# Altium schematic sheet MCU.SchDoc
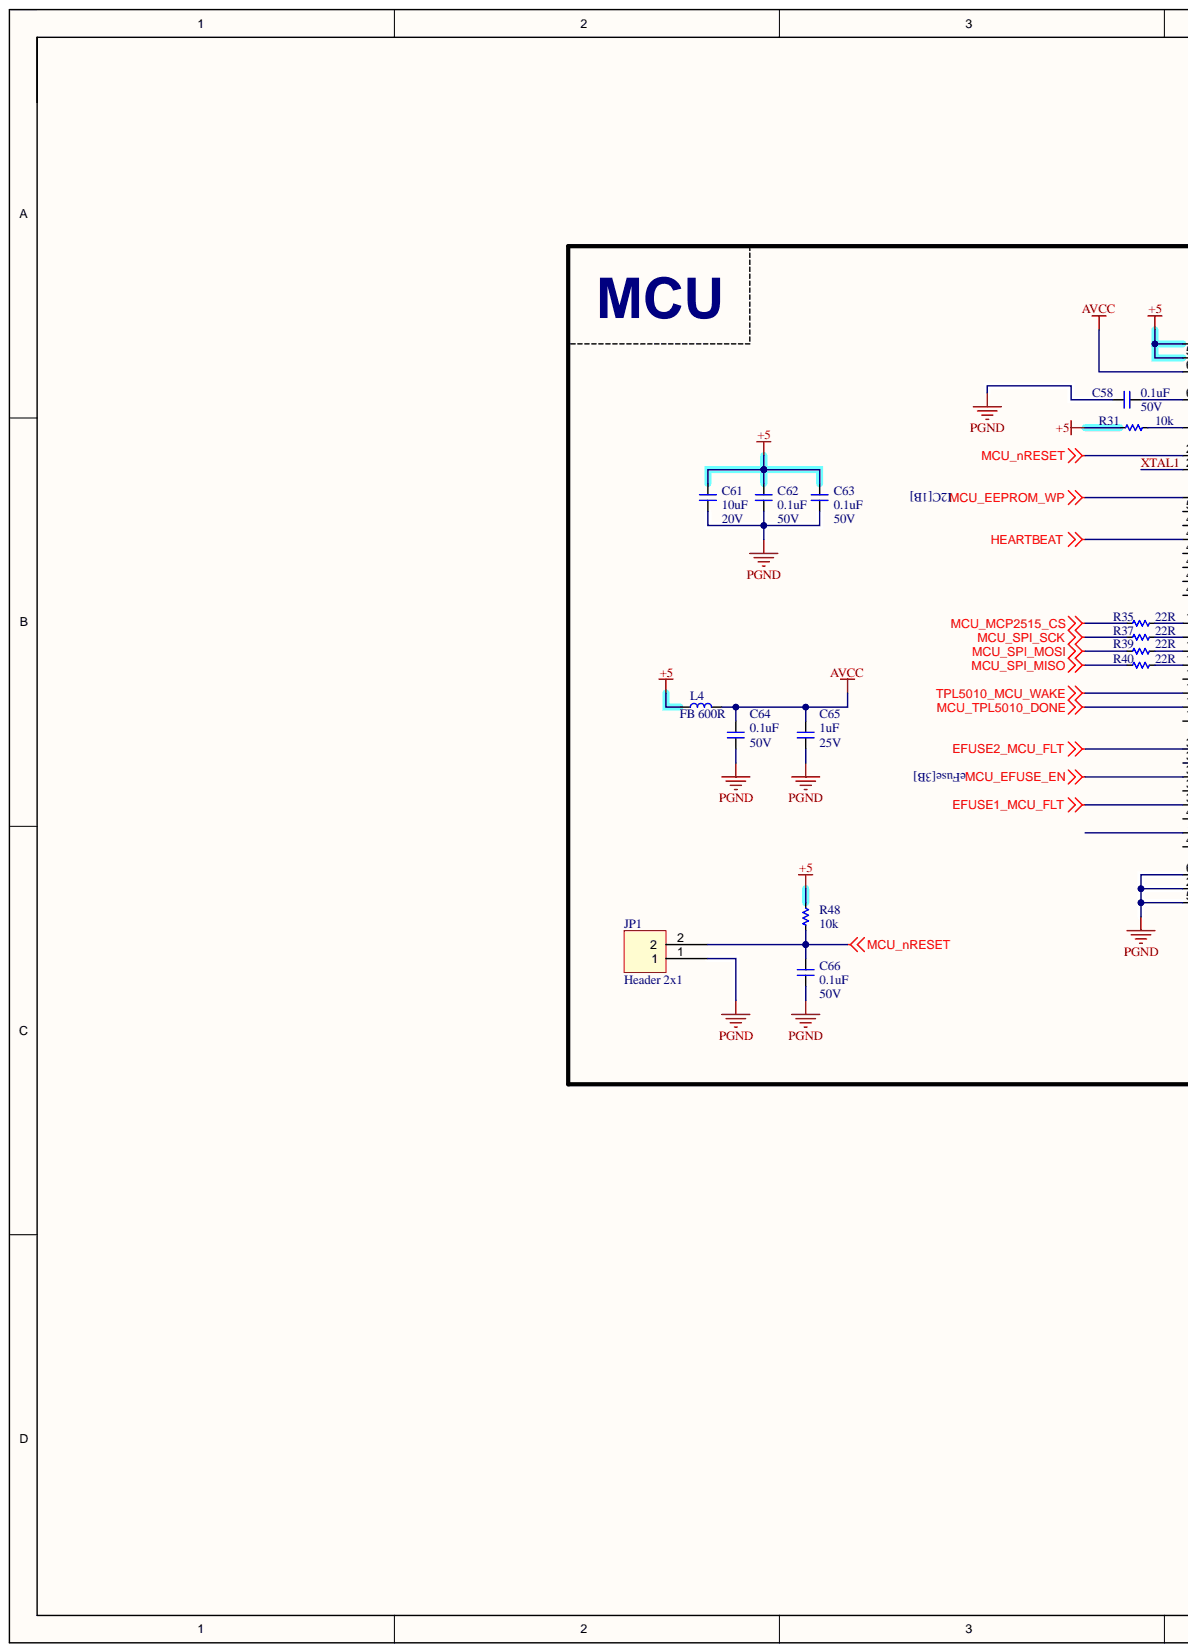
[diagram p0: MCU.SchDoc, left]
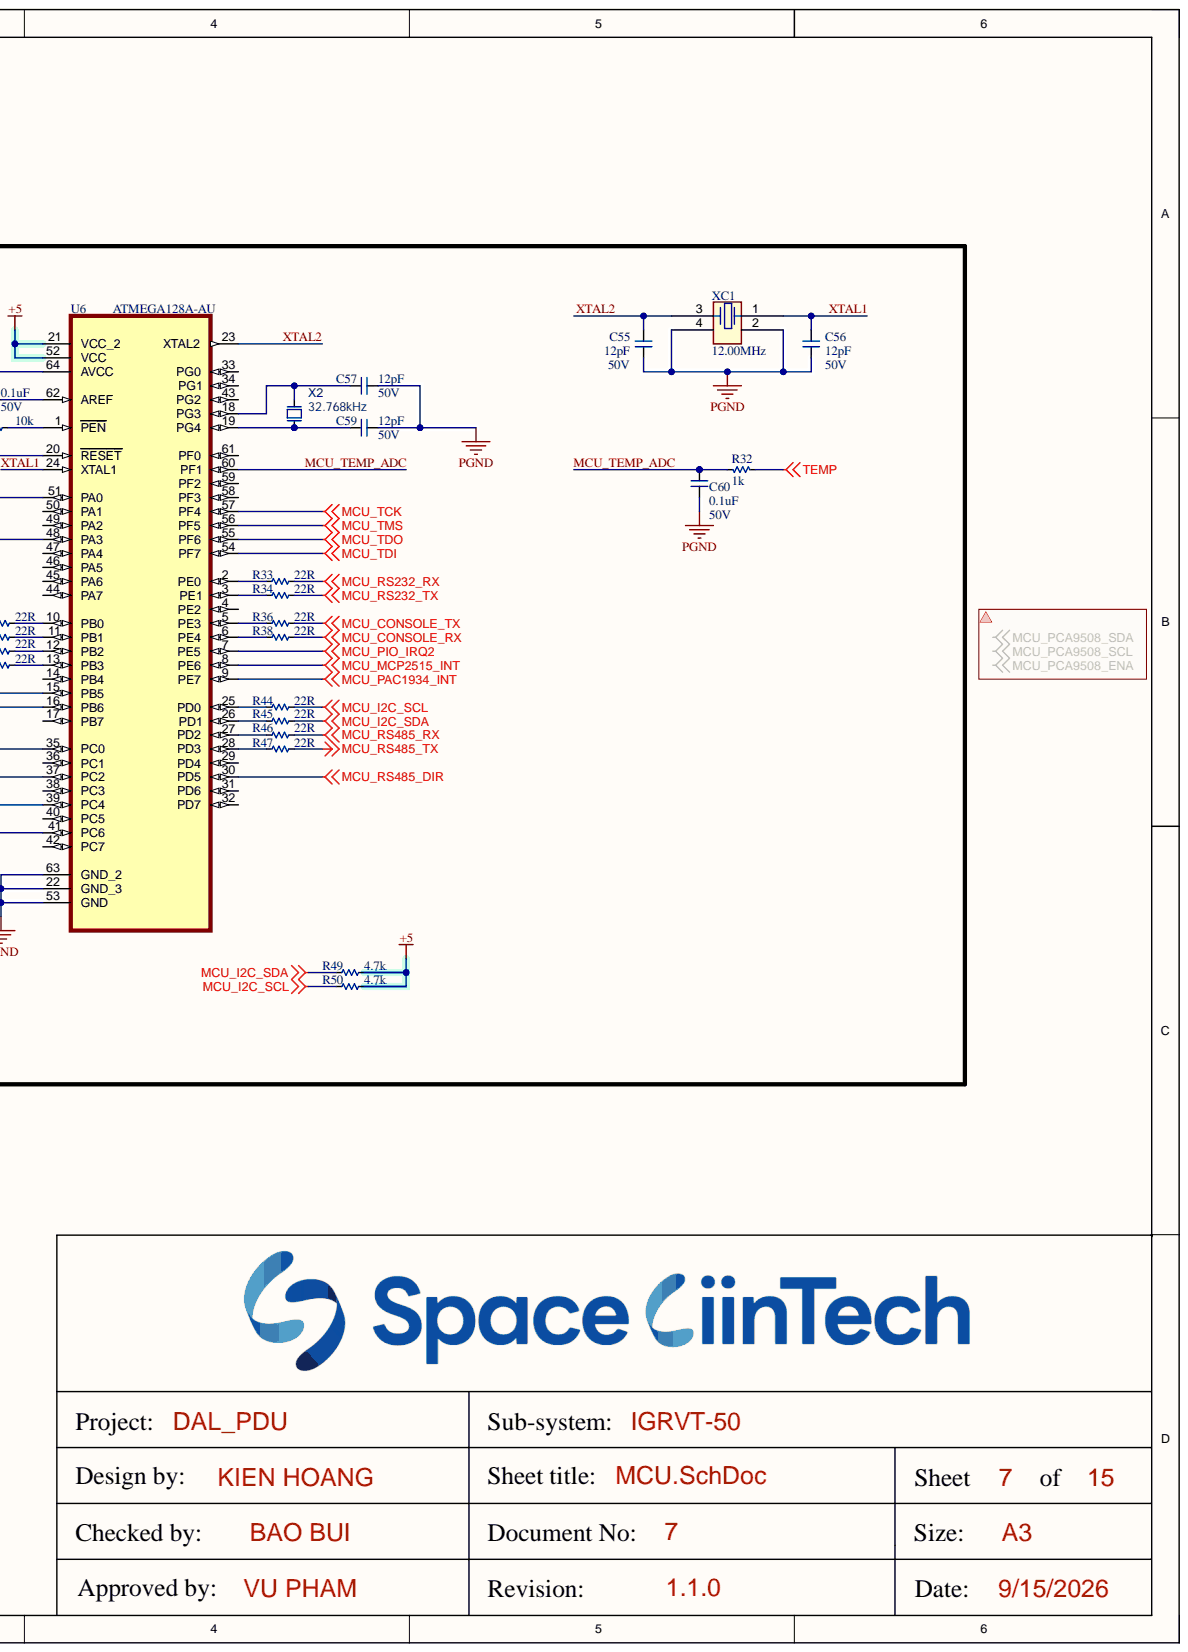
[diagram p1: MCU.SchDoc, right]

## Parts
C55  12pF  Voltage: 50V  [CAP_C_1608X80]
C56  12pF  Voltage: 50V  [CAP_C_1608X80]
C57  12pF  Voltage: 50V  [CAP_C_1608X80]
C58  0.1uF  Voltage: 50V  [CAP_C_1608X80]
C59  12pF  Voltage: 50V  [CAP_C_1608X80]
C60  0.1uF  Voltage: 50V  [CAP_C_1608X80]
C61  10uF  Voltage: 20V  [CAP_C_2012X110]
C62  0.1uF  Voltage: 50V  [CAP_C_1608X80]
C63  0.1uF  Voltage: 50V  [CAP_C_1608X80]
C64  0.1uF  Voltage: 50V  [CAP_C_1608X80]
C65  1uF  Voltage: 25V  [CAP_C_1608X80]
C66  0.1uF  Voltage: 50V  [CAP_C_1608X80]
JP1  Header 2x1  [Header_254P_2x1]
L4  FB 600R  [L-SMD-0603]
R31  10k  [RES_C_1608X60]
R32  1k  [RES_C_1608X60]
R33  22R  [RES_C_1608X60]
R34  22R  [RES_C_1608X60]
R35  22R  [RES_C_1608X60]
R36  22R  [RES_C_1608X60]
R37  22R  [RES_C_1608X60]
R38  22R  [RES_C_1608X60]
R39  22R  [RES_C_1608X60]
R40  22R  [RES_C_1608X60]
R44  22R  [RES_C_1608X60]
R45  22R  [RES_C_1608X60]
R46  22R  [RES_C_1608X60]
R47  22R  [RES_C_1608X60]
R48  10k  [RES_C_1608X60]
R49  4.7k  [RES_C_1608X60]
R50  4.7k  [RES_C_1608X60]
U6  ATMEGA128A-AU  [TQFP-64]
X2  value 32.768kHz  [CRYSTAL_NX2012SA]  (hidden comment: Crystal 2 Pins)
XC1  value 12.00MHz  [AP7-00039]  (hidden comment: FC5BQCCMC12.0-T1)

## Nets
+5: C61.2 C62.1 C63.1 L4.1 R31.1 R48.1 R49.1 R50.1 U6.21(VCC_2) U6.52(VCC)
AVCC: C64.1 C65.1 L4.2 U6.64(AVCC)
EFUSE1_MCU_FLT: U6.39(PC4)
EFUSE2_MCU_FLT: U6.35(PC0)
HEARTBEAT: U6.48(PA3)
MCU_CONSOLE_RX: R38.2
MCU_CONSOLE_TX: R36.2
MCU_EEPROM_WP: U6.51(PA0)
MCU_EFUSE_EN: U6.37(PC2)
MCU_I2C_SCL: R44.2 R50.2
MCU_I2C_SDA: R45.2 R49.2
MCU_MCP2515_CS: R35.1
MCU_MCP2515_INT: U6.8(PE6)
MCU_nRESET: C66.2 JP1.2 R48.2 U6.20(RESET)
MCU_PAC1934_INT: U6.9(PE7)
MCU_PIO_IRQ2: U6.7(PE5)
MCU_RS232_RX: R33.2
MCU_RS232_TX: R34.2
MCU_RS485_DIR: U6.30(PD5)
MCU_RS485_RX: R46.2
MCU_RS485_TX: R47.2
MCU_SPI_MISO: R40.1
MCU_SPI_MOSI: R39.1
MCU_SPI_SCK: R37.1
MCU_TCK: U6.57(PF4)
MCU_TDI: U6.54(PF7)
MCU_TDO: U6.55(PF6)
MCU_TMS: U6.56(PF5)
MCU_TPL5010_DONE: U6.16(PB6)
PGND: C55.1 C56.1 C57.1 C58.2 C59.1 C60.2 C61.1 C62.2 C63.2 C64.2 C65.2 C66.1 JP1.1 U6.22(GND_3) U6.53(GND) U6.63(GND_2) XC1.2 XC1.4
TEMP: R32.1
TPL5010_MCU_WAKE: U6.15(PB5)
MCU_TEMP_ADC: C60.1 R32.2 U6.60(PF1)
XTAL1: C56.2 U6.24(XTAL1) XC1.1
XTAL2: C55.2 U6.23(XTAL2) XC1.3
NetC57_2: C57.2 U6.18(PG3) X2.2
NetC58_1: C58.1 U6.62(AREF)
NetC59_2: C59.2 U6.19(PG4) X2.1
NetR31_2: R31.2 U6.1(PEN)
NetR33_1: R33.1 U6.2(PE0)
NetR34_1: R34.1 U6.3(PE1)
NetR35_2: R35.2 U6.10(PB0)
NetR36_1: R36.1 U6.5(PE3)
NetR37_2: R37.2 U6.11(PB1)
NetR38_1: R38.1 U6.6(PE4)
NetR39_2: R39.2 U6.12(PB2)
NetR40_2: R40.2 U6.13(PB3)
NetR44_1: R44.1 U6.25(PD0)
NetR45_1: R45.1 U6.26(PD1)
NetR46_1: R46.1 U6.27(PD2)
NetR47_1: R47.1 U6.28(PD3)

Not connected: U6 4(PE2) 14(PB4) 17(PB7) 29(PD4) 31(PD6) 32(PD7) 33(PG0) 34(PG1) 36(PC1) 38(PC3) 40(PC5) 41(PC6) 42(PC7) 43(PG2) 44(PA7) 45(PA6) 46(PA5) 47(PA4) 49(PA2) 50(PA1) 58(PF3) 59(PF2) 61(PF0)

## Library data of the parts
C55-C66 = _CAP_C_2: CAP; Component Kind: Standard; Library Name: LABLIB.SchLib
L4 = Inductor: Ferrite Bread; Component Kind: Standard; Library Name: chipfc_libs.SchLib
R31-R40 R44-R50 = _RES_B_2_2: RES; Component Kind: Standard; Library Name: LABLIB.SchLib
X2 = OSC_32.768kHz: Crystal; Manufacturer 1: NDK; Manufacturer Part Number 1: NX2012SA-32.768K-STD-MUB-1; Description_: CRYSTAL 32.768kHz 12.5pF SMD NX2012SA; Dev Tools Status: Production; Load Capacitance: 12.5pF; MCHP-ID: OSC1092; Owner: Jamus Griego; Populated: YES; Tolerance: ±20ppm; Category: Crystal/Oscillator; Component Kind: Standard; ComponentLink1Description: SVN Datasheet; ComponentLink1URL: https://chn-vm-apps.microchip.com/mchprepo/parts/Datasheets/c_NX2012SA-STD-MUB-1_e.pdf; ComponentLink2Description: Local Datasheet; ComponentLink3Description: Open Symbol; ComponentLink4Description: Open Footprint; ComponentLink5Description: Open Footprint 2; ComponentLink6Description: Open Footprint 3; ComponentLink7Description: Open Footprint 4; Quantity Override: 1; Revision: 1; Status: MCL Design; Supplier 1: Digi-Key; Supplier Part Number 1: 644-1219-1-ND; Symbol: Crystal 2 Pins; Type: Crystal; ComponentLink2URL: C:\ALTIUM_WORK\00001_MCHP-CDB\Datasheets\c_NX2012SA-STD-MUB-1_e.pdf; ComponentLink3URL: C:\ALTIUM_WORK\00001_MCHP-CDB\Library\LibraryRefs\Crystal 2 Pins.SchLib; ComponentLink4URL: C:\ALTIUM_WORK\00001_MCHP-CDB\Library\Footprints\CRYSTAL_NX2012SA.PcbLib; Library Name: LABLIB.SchLib
XC1 = A07-0042: Fox FQ5032B 12.0MHz SMD crystal 738B-12; Manufacturer: Fox  Electronics; MPN: FQ5032B-12.000; PartGroup: Frequency devices,Crystals,SMD; Database Table Name: AltiumComponents; TemperatureRange: 0Â°C ~ 70Â°C; Tolerance: Â±30ppm; AN: A07-0042; Component Kind: Standard; Height: 1,20000004768372; Library Name: AltiumDbLib.DbLib
JP1 = Header 2x1: Component Kind: Standard; Library Name: LABLIB.SchLib
U6 = ATMEGA128A-AU: Component Kind: Standard; Library Name: KIENASIY123_LIB.IntLib
